# Altium schematic sheet CMOY Pro 1.0.SchDoc("CMOY Pro 1.0")
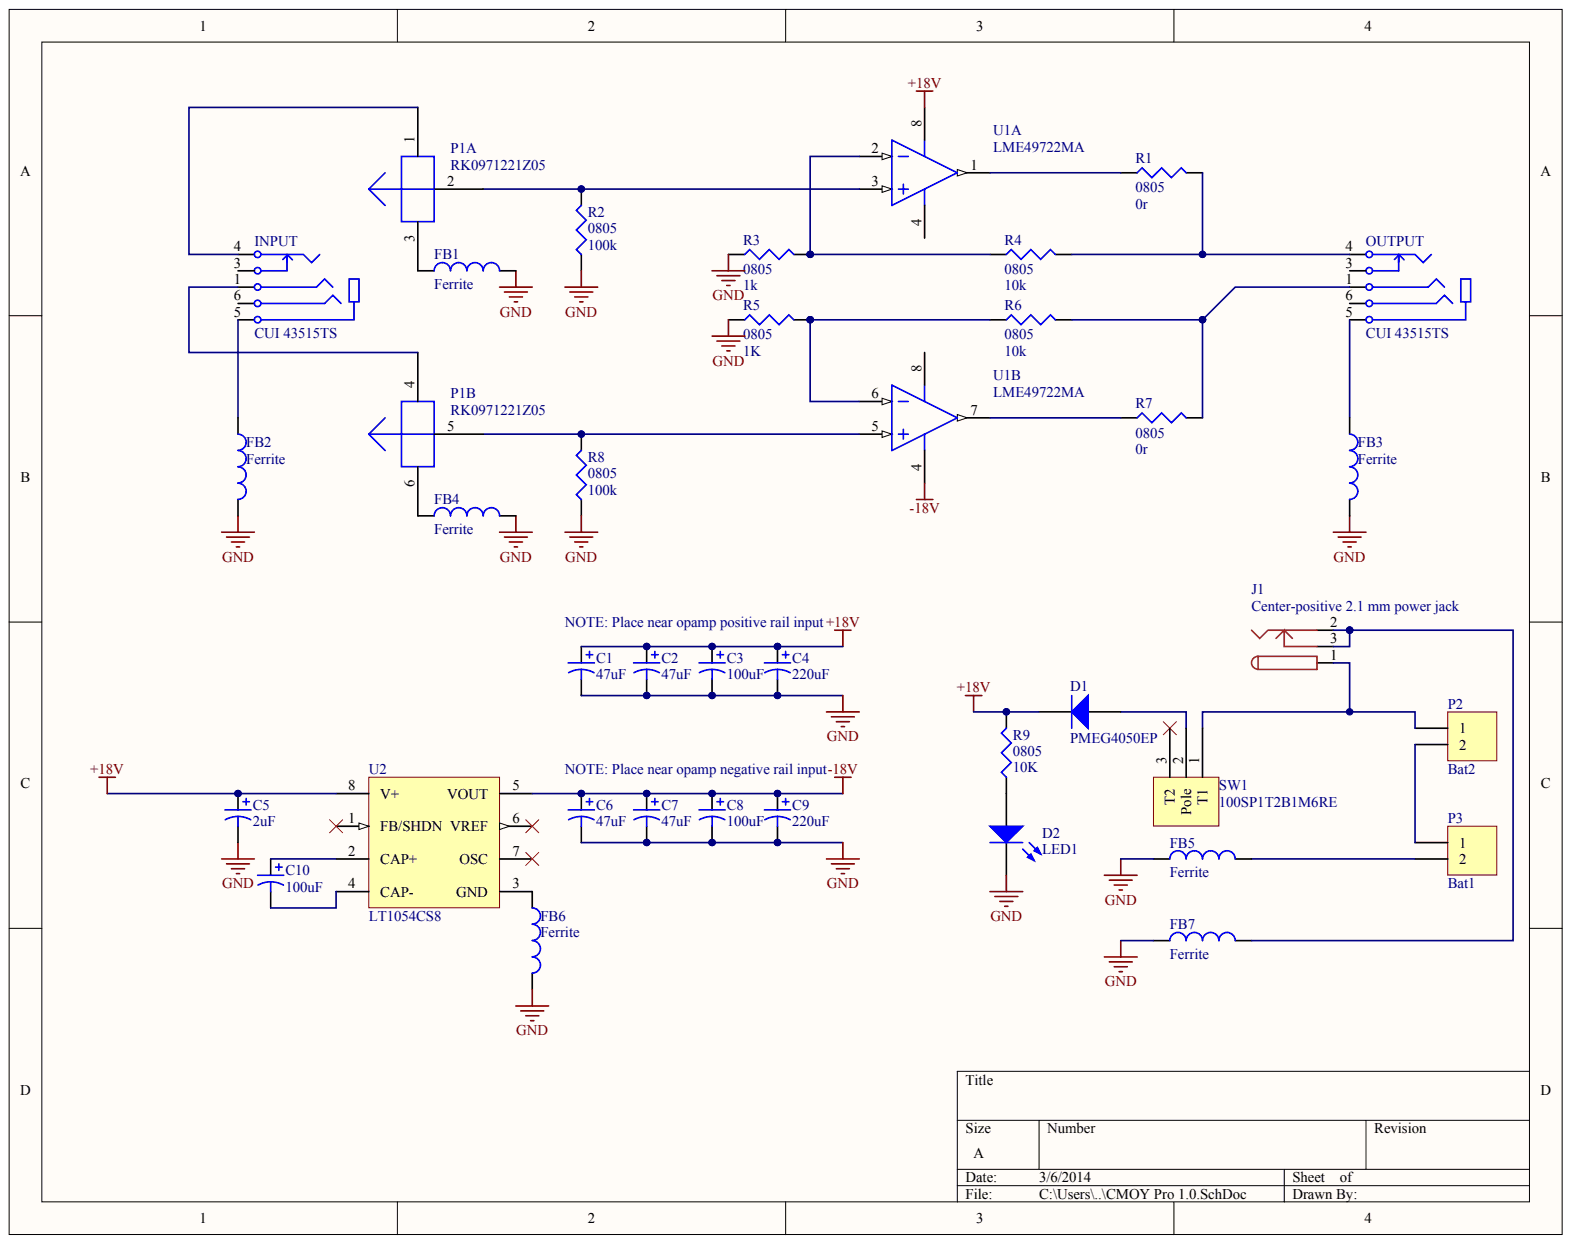
[diagram p0: CMOY Pro 1.0.SchDoc]

## Parts
C1  value 47uF  (hidden comment: Cap Pol1)
C2  value 47uF  (hidden comment: Cap Pol1)
C3  value 100uF  (hidden comment: Cap Pol1)
C4  value 220uF  (hidden comment: Cap Pol1)
C5  value 2uF  (hidden comment: Cap Pol1)
C6  value 47uF  (hidden comment: Cap Pol1)
C7  value 47uF  (hidden comment: Cap Pol1)
C8  value 100uF  (hidden comment: Cap Pol1)
C9  value 220uF  (hidden comment: Cap Pol1)
C10  value 100uF  (hidden comment: Cap Pol1)
D1  PMEG4050EP
D2  LED1
FB1  Ferrite
FB2  Ferrite
FB3  Ferrite
FB4  Ferrite
FB5  Ferrite
FB6  Ferrite
FB7  Ferrite
INPUT  CUI 43515TS
J1  Center-positive 2.1 mm power jack
OUTPUT  CUI 43515TS
P1  RK0971221Z05  (parts A,B)
P2  Bat2
P3  Bat1
R1  0805  value 0r
R2  0805  value 100k
R3  0805  value 1k
R4  0805  value 10k
R5  0805  value 1K
R6  0805  value 10k
R7  0805  value 0r
R8  0805  value 100k
R9  0805  value 10K
SW1  100SP1T2B1M6RE
U1  LME49722MA  (parts A,B)
U2  LT1054CS8

## Nets
+18V: C1.1 C2.1 C3.1 C4.1 C5.1 D1.1 R9.2 U1.8 U2.8(V+)
-18V: C6.1 C7.1 C8.1 C9.1 U1.4 U2.5(VOUT)
GND: C1.2 C2.2 C3.2 C4.2 C5.2 C6.2 C7.2 C8.2 C9.2 D2.1 FB1.2 FB2.2 FB3.2 FB4.2 FB5.1 FB6.2 FB7.1 R2.1 R3.1 R5.1 R8.1
NetC10_1: C10.1 U2.2(CAP+)
NetC10_2: C10.2 U2.4(CAP-)
NetD1_2: D1.2 SW1.2
NetD2_2: D2.2 R9.1
NetFB1_1: FB1.1 P1.3
NetFB2_1: FB2.1 INPUT.5
NetFB3_1: FB3.1 OUTPUT.5
NetFB4_1: FB4.1 P1.6
NetFB5_2: FB5.2 P3.2
NetFB6_1: FB6.1 U2.3(GND)
NetFB7_2: FB7.2 J1.2 J1.3
NetINPUT_1: INPUT.1 P1.4
NetINPUT_4: INPUT.4 P1.1
NetJ1_1: J1.1 P2.1 SW1.1
NetOUTPUT_1: OUTPUT.1 R6.2 R7.2
NetOUTPUT_4: OUTPUT.4 R1.2 R4.2
NetP1_2: P1.2 R2.2 U1.3
NetP1_5: P1.5 R8.2 U1.5
NetP2_2: P2.2 P3.1
NetR1_1: R1.1 U1.1
NetR3_2: R3.2 R4.1 U1.2
NetR5_2: R5.2 R6.1 U1.6
NetR7_1: R7.1 U1.7

Not connected: INPUT 3 6; OUTPUT 3 6; SW1 3; U2 1(FB/SHDN) 6(VREF) 7(OSC)

## Library data of the parts
FB1-FB7 = (no symbol name): Code_IEC: 1005; Published: 8-Jun-2000; LatestRevisionDate: 17-Jul-2002; LatestRevisionNote: Re-released for DXP Platform.; PackageReference: 0402-A; Code_JEITA: 0402; Publisher: Altium Limited
R3 = (no symbol name): Value: 1k; Published: 8-Jun-2000; LatestRevisionDate: 29-May-2009; LatestRevisionNote: IPC-7351 Footprint Added.; PackageReference: J1-0603; PackageDescription: Chip Resistor; Publisher: Altium Limited
R4 = (no symbol name): Value: 10k; Published: 8-Jun-2000; LatestRevisionDate: 29-May-2009; LatestRevisionNote: IPC-7351 Footprint Added.; PackageReference: J1-0603; PackageDescription: Chip Resistor; Publisher: Altium Limited
U1 = (no symbol name): Manufacturer: National Semiconductor; PackageTopMarking: NSZXTT*L49722*MA; PowerWise: N; CompType: Audio IC; High-Reliability: Y; DatasheetVersion: Mar-2008; PackageVersion: revM, May-2009; PackageReference: M08A; PackageDescription: SOIC Narrow, 4.9 x 3.9 x 1.45mm, 8 Lead, 1.27mm Pitch; PartNumber: LME49722MA; RoHS: Y; PinCount: 8; Code_JEDEC: MS-012-AA; Code_IPC: SOIC127P600-8; ComponentLink1Description: Manufacturer URL; ComponentLink1URL: http://www.national.com/; ComponentLink2Description: LME49722 Datasheet; ComponentLink2URL: http://www.national.com/ds/LM/LME49722.pdf; ComponentLink3Description: LME49722 Web Page; ComponentLink3URL: http://www.national.com/pf/LM/LME49722.html; ComponentLink4Description: M08A Package Page; ComponentLink4URL: http://www.national.com/packaging/folders/m08a.html
U2 = (no symbol name): Manufacturer: Linear Technology; Frequency(kHz): 25; Ishutdown(uA): 100; Isupply(mA): 2.5; Vin Max(V): 15; Vin Min(V): 3.5; Voutput: Adj; DatasheetVersion: Rev. G; PackageVersion: Rev. G, 03/2012; PackageReference: S8-8; PackageDescription: 8-Pin Plastic Small Outline (Narrow .150 Inch), Body 4.9 x 3.9 mm, Pitch 1.27 mm; Supplier 1: Digi-Key; Supplier Part Number 1: LT1054CS8-ND; Supplier 2: Newark; Supplier Part Number 2: 57M1187; Max Iout(mA): 100; RoHS: FALSE; Mounting Technology: Surface Mount; PartNumber: LT1054CS8; ComponentLink1Description: Manufacturer URL; ComponentLink1URL: http://www.linear.com/; ComponentLink2Description: Datasheet; ComponentLink2URL: http://cds.linear.com/docs/en/datasheet/1054lfg.pdf; ComponentLink3Description: Package Specification; ComponentLink3URL: http://cds.linear.com/docs/en/packaging/05081610_G_SO8.pdf
C1-C10 D1 D2 INPUT J1 OUTPUT P1-P3 R1 R2 R5-R9 SW1 = (no symbol name)
  C1-C10: Published: 8-Jun-2000; LatestRevisionDate: 17-Jul-2002; LatestRevisionNote: Re-released for DXP Platform.; PackageReference: RB7.6-15; PackageDescription: Polarized Capacitor (Radial); 2 Leads
  D1: Published: 8-Jun-2000; LatestRevisionDate: 17-Jul-2002; LatestRevisionNote: Re-released for DXP Platform.; PackageVersion: Sep-1996; PackageReference: SMC; PackageDescription: DO-214-AB/SMC; 2 C-Bend Leads; Body 7.9 x 5.9 mm, inc. leads (LxW); Code_JEDEC: DO-214-AB
  D2: Published: 8-Jun-2000; LatestRevisionDate: 08-Jul-2005; LatestRevisionNote: Mech Layer 1 removed.; PackageReference: LED-1; PackageDescription: LED; 2 Leads
  J1: Published: 17-Dec-2003; DatasheetDocument: 09-May-2002; ComponentLink1Description: Manufacturer Link; ComponentLink1URL: http://domino.kycon.com/internet/Products.html
  P2 P3: LatestRevisionDate: 17-Jul-2002; LatestRevisionNote: Re-released for DXP Platform.
  R1 R2 R5-R9: Published: 8-Jun-2000; LatestRevisionDate: 29-May-2009; LatestRevisionNote: IPC-7351 Footprint Added.; PackageReference: J1-0603; PackageDescription: Chip Resistor
  C1-C10 D1 D2 J1 P2 P3 R1 R2 R5-R9: Publisher: Altium Limited
